# Altium schematic sheet 019_FlashNAND.SchDoc(019_FlashNAND)
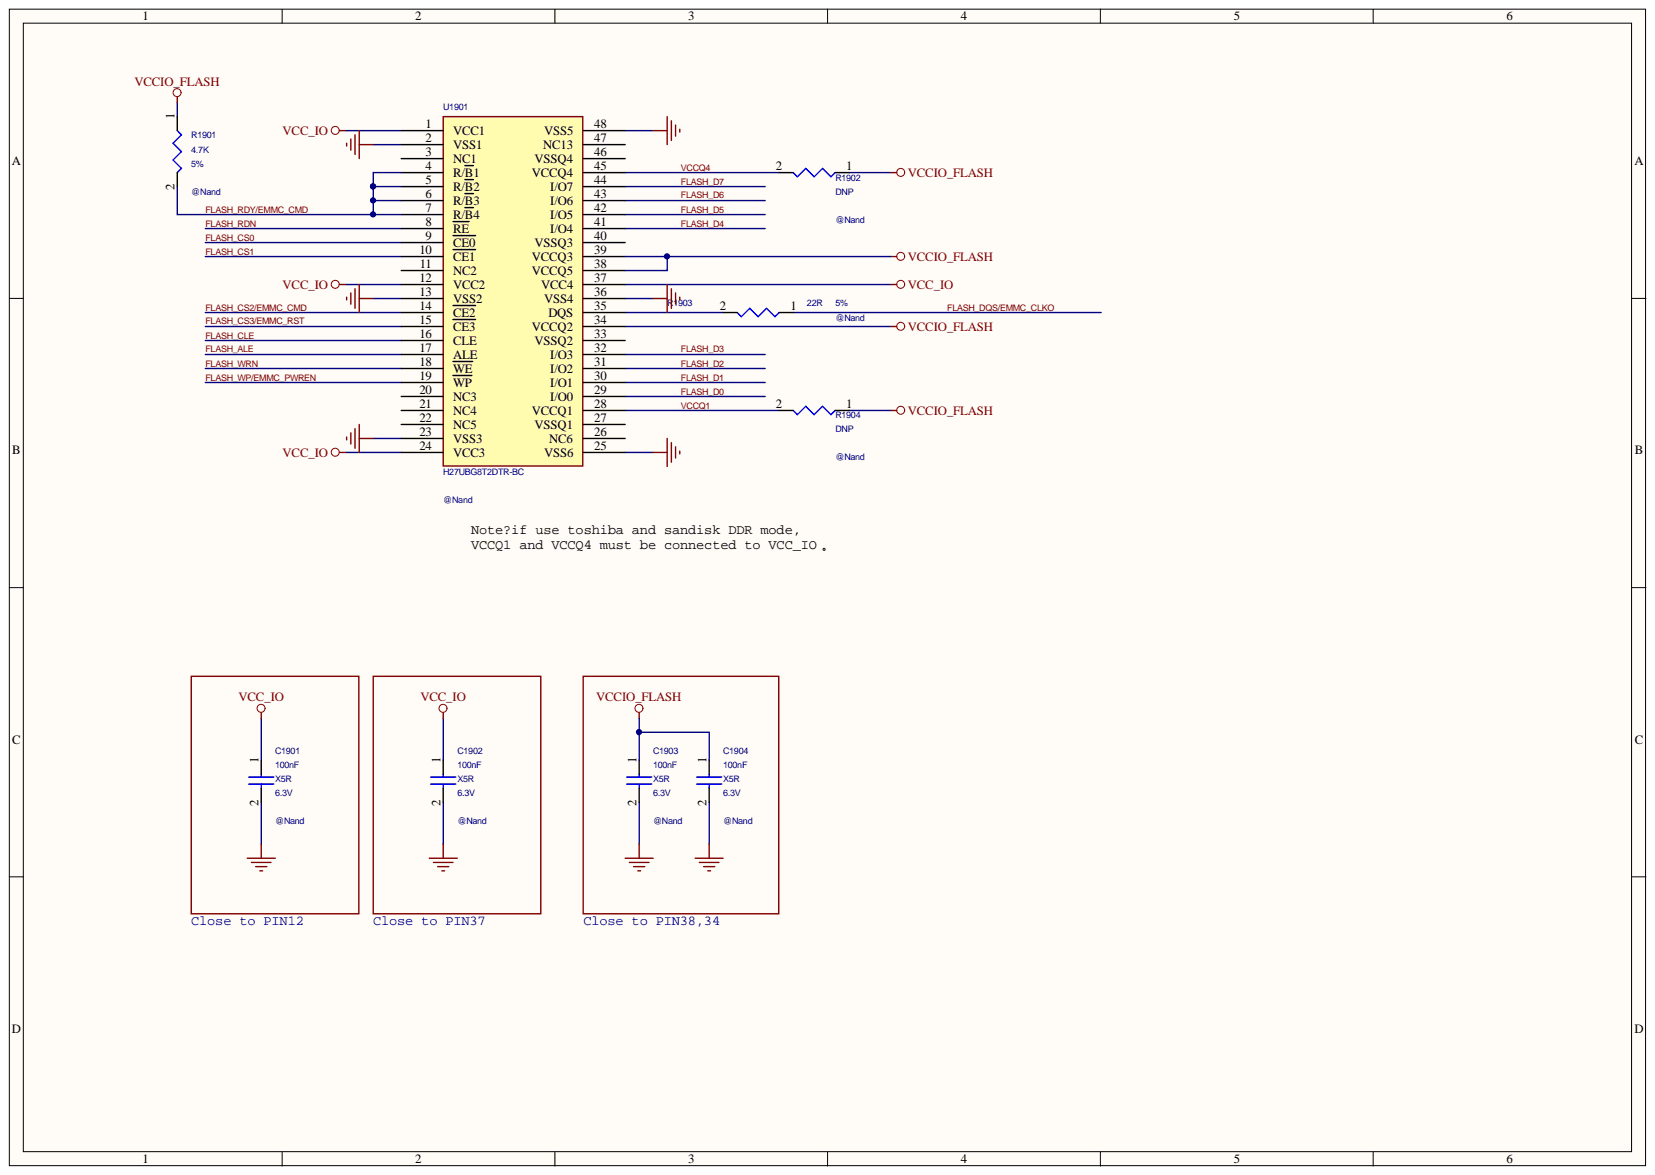
[diagram p0: 019_FlashNAND.SchDoc]

## Parts
C1901  100nF  Voltage: 6.3V  Option: @Nand  Dielectric: X5R  [C0402]
C1902  100nF  Voltage: 6.3V  Option: @Nand  Dielectric: X5R  [C0402]
C1903  100nF  Voltage: 6.3V  Option: @Nand  Dielectric: X5R  [C0402]
C1904  100nF  Voltage: 6.3V  Option: @Nand  Dielectric: X5R  [C0402]
R1901  4.7K  Tolerance: 5%  Option: @Nand  [R0402]
R1902  DNP  [R0402]
R1903  22R  [R0402]
R1904  DNP  [R0402]
U1901  H27UBG8T2DTR-BC  [TSOP1-48]

## Nets
GND: C1901.2 C1902.2 C1903.2 C1904.2 U1901.2(VSS1) U1901.13(VSS2) U1901.23(VSS3) U1901.25(VSS6) U1901.36(VSS4) U1901.48(VSS5)
VCC_IO: C1901.1 C1902.1 U1901.1(VCC1) U1901.12(VCC2) U1901.24(VCC3) U1901.37(VCC4)
VCCIO_FLASH: C1903.1 C1904.1 R1901.1 R1902.1 R1904.1 U1901.34(VCCQ2) U1901.38(VCCQ5) U1901.39(VCCQ3)
FLASH_ALE: U1901.17(ALE)
FLASH_CLE: U1901.16(CLE)
FLASH_CS0: U1901.9(CE0)
FLASH_CS1: U1901.10(CE1)
FLASH_CS2/EMMC_CMD: U1901.14(CE2)
FLASH_CS3/EMMC_RST: U1901.15(CE3)
FLASH_D0: U1901.29(I/O0)
FLASH_D1: U1901.30(I/O1)
FLASH_D2: U1901.31(I/O2)
FLASH_D3: U1901.32(I/O3)
FLASH_D4: U1901.41(I/O4)
FLASH_D5: U1901.42(I/O5)
FLASH_D6: U1901.43(I/O6)
FLASH_D7: U1901.44(I/O7)
FLASH_DQS/EMMC_CLKO: R1903.1
FLASH_RDN: U1901.8(RE)
FLASH_RDY/EMMC_CMD: R1901.2 U1901.4(R/B1) U1901.5(R/B2) U1901.6(R/B3) U1901.7(R/B4)
FLASH_WP/EMMC_PWREN: U1901.19(WP)
FLASH_WRN: U1901.18(WE)
VCCQ1: R1904.2 U1901.28(VCCQ1)
VCCQ4: R1902.2 U1901.45(VCCQ4)
NetR1903_2: R1903.2 U1901.35(DQS)

Not connected: U1901 3(NC1) 11(NC2) 20(NC3) 21(NC4) 22(NC5) 26(NC6) 27(VSSQ1) 33(VSSQ2) 40(VSSQ3) 46(VSSQ4) 47(NC13)

## Library data of the parts
C1901-C1904 = CAP NP_Dup5: cap,100.00nF,+/-10%,6.3V,X5R,C0402; Manufacturer: TDK; Tolerance: 10%; RK PN: C1005X5R0J104K050BA; Priority: A; Number: 37; Part Type: C0402; Manufacturer PN: C1005X5R0J104K050BA; Component Kind: Standard; C_Voltage: 6.3V; Created by: JJJ
R1901 = RESISTOR_Dup1: 哥棨슌훡롯量,4K7,+/-5%,R0402,1/16W.; Manufacturer: YAGEO; Wattage: 1/16W; RK PN: RC0402JR-074K7L; Priority: A; Part Type: 哥棨슌훡롯量; Manufacturer PN: RC0402JR-074K7L; Component Kind: Standard; Created by: YTL
R1902 R1904 = RESISTOR_Dup1: Did Not Place.; Tolerance: 0%; RK PN: DNP0402; Priority: A; Option: @Nand; Part Type: DNP; Manufacturer PN: DNP0402; Component Kind: Standard; Created by: JJJ
R1903 = RESISTOR_Dup1: 哥棨슌훡롯量,22R,+/-5%,R0402,1/16W.; Manufacturer: YAGEO; Wattage: 1/16W; Tolerance: 5%; RK PN: RC0402JR-0722RL; Priority: A; Option: @Nand; Part Type: 哥棨슌훡롯量; Manufacturer PN: RC0402JR-0722RL; Component Kind: Standard; Created by: YTL
U1901 = NANDFLASH_TSOP48: 32Gb; Manufacturer: hynix(삯텝야); RK PN: NAND_FLASH; Priority: A; Option: @Nand; Part Type: NAND_FLASH; Manufacturer PN: H27UBG8T2DTR-BC; Component Kind: Standard; Created by: JJJ
